# Altium schematic sheet SmithBoard Rev B.SchDoc
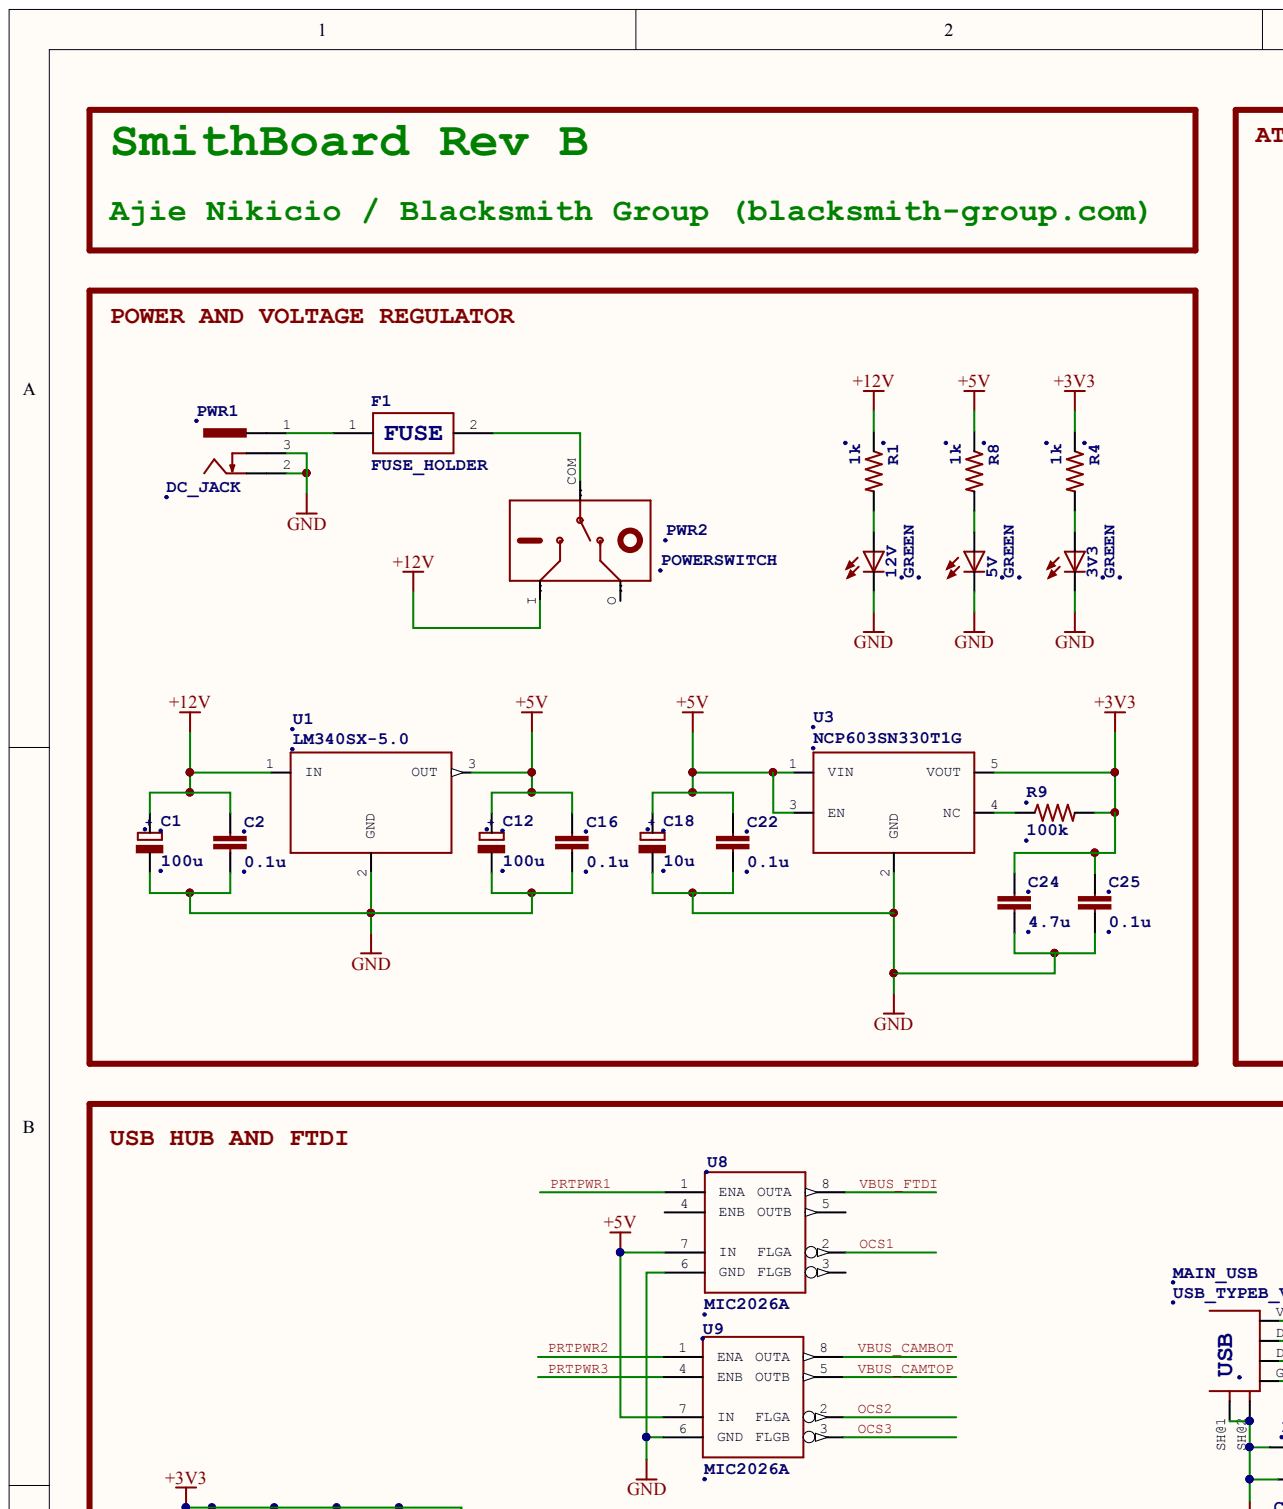
[diagram p0: SmithBoard Rev B.SchDoc, top left]
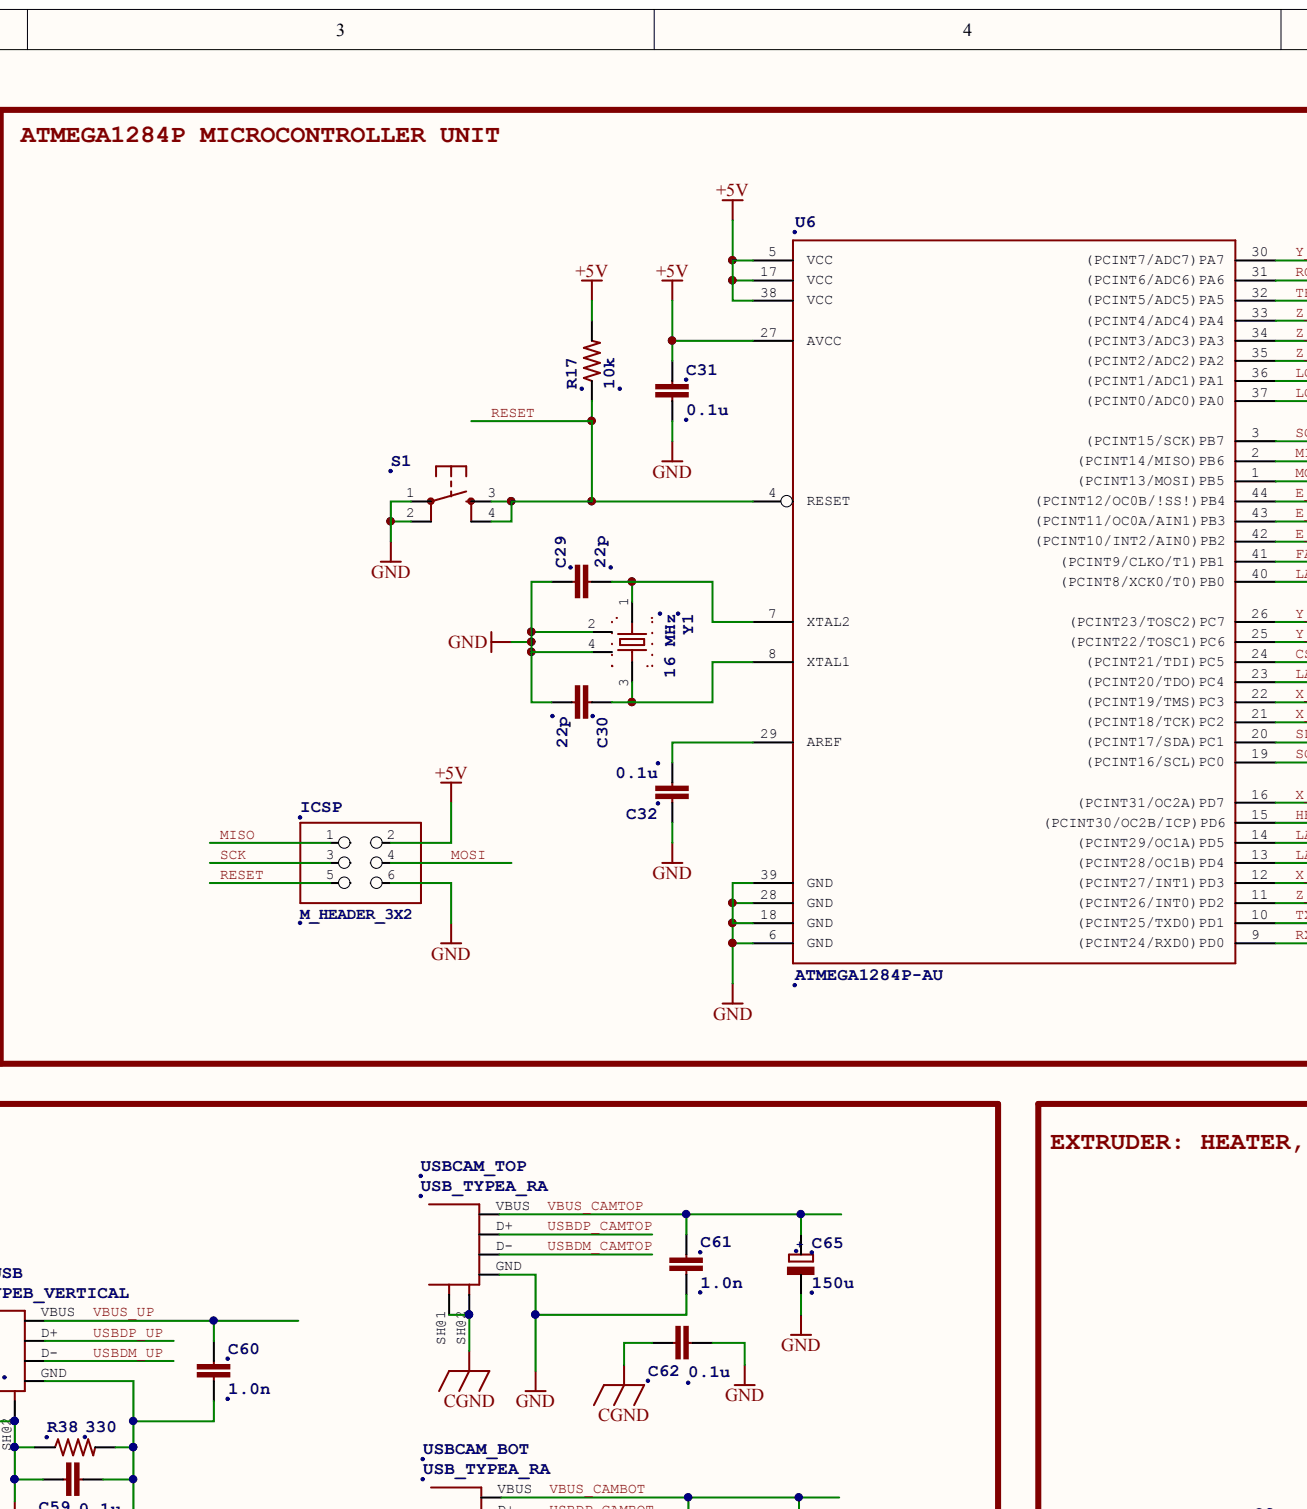
[diagram p1: SmithBoard Rev B.SchDoc, top centre]
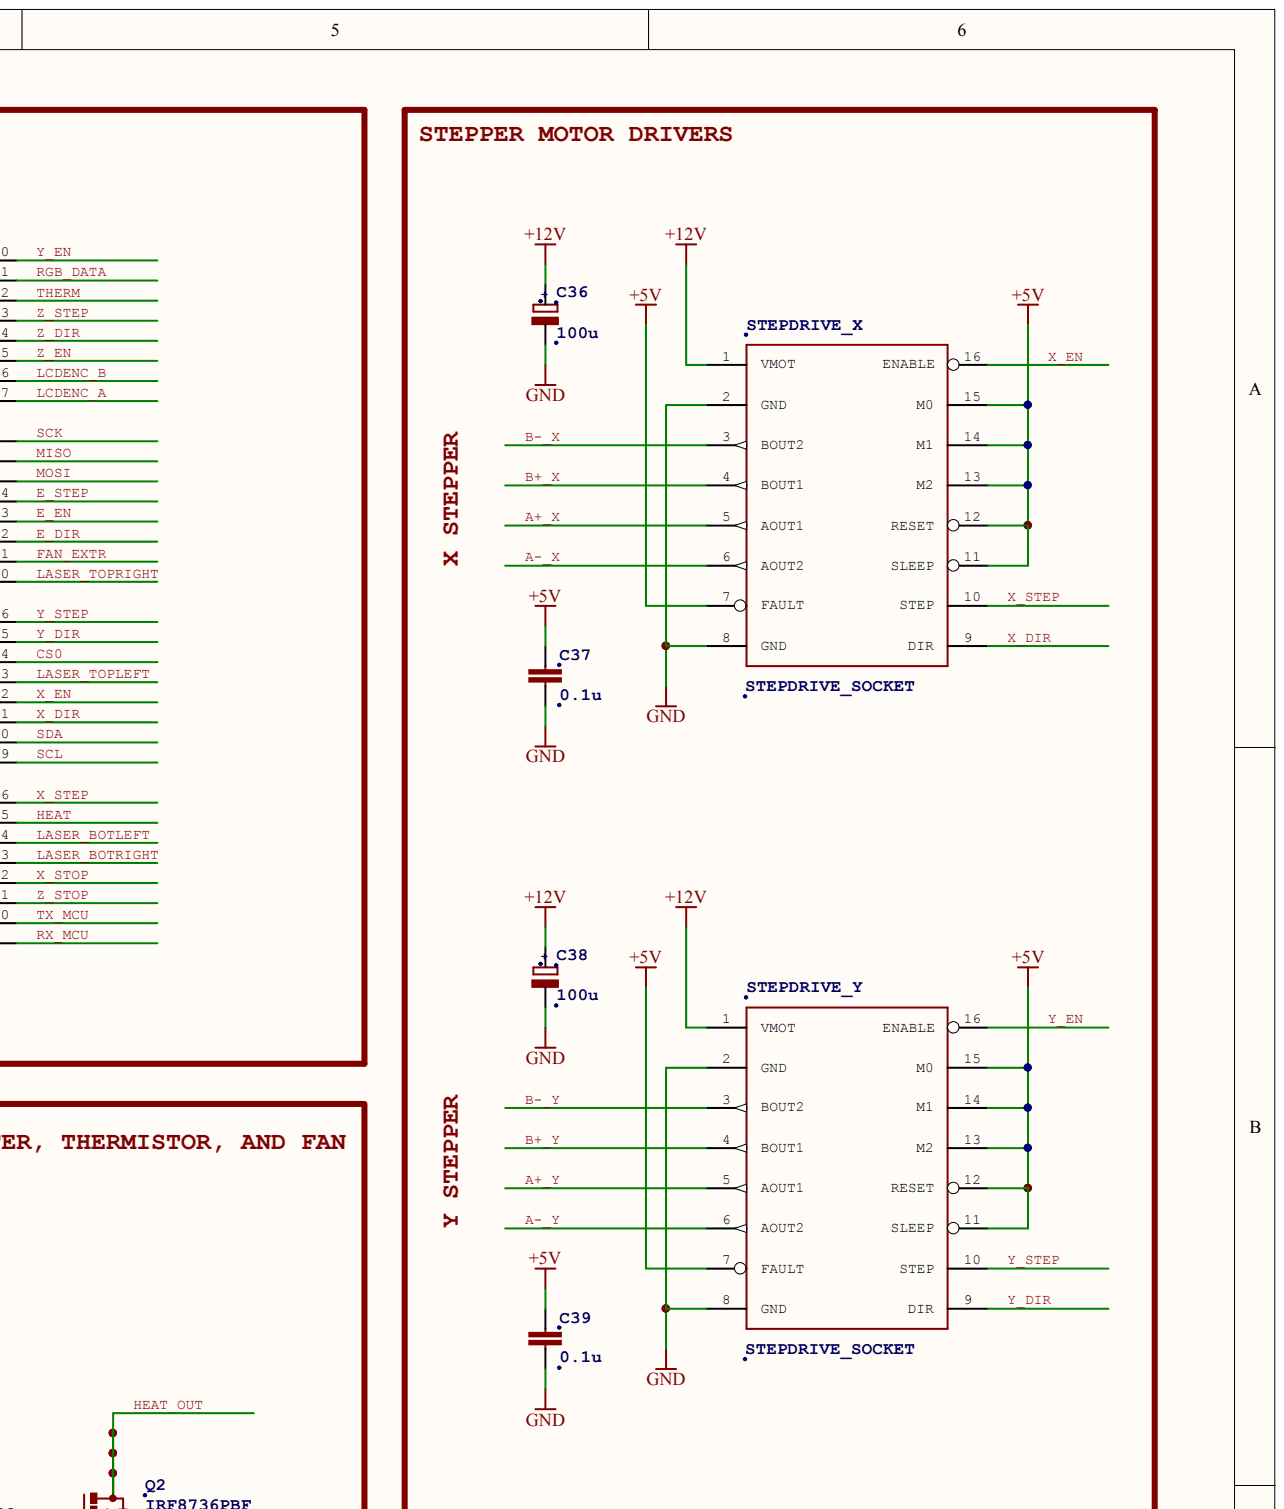
[diagram p2: SmithBoard Rev B.SchDoc, top right]
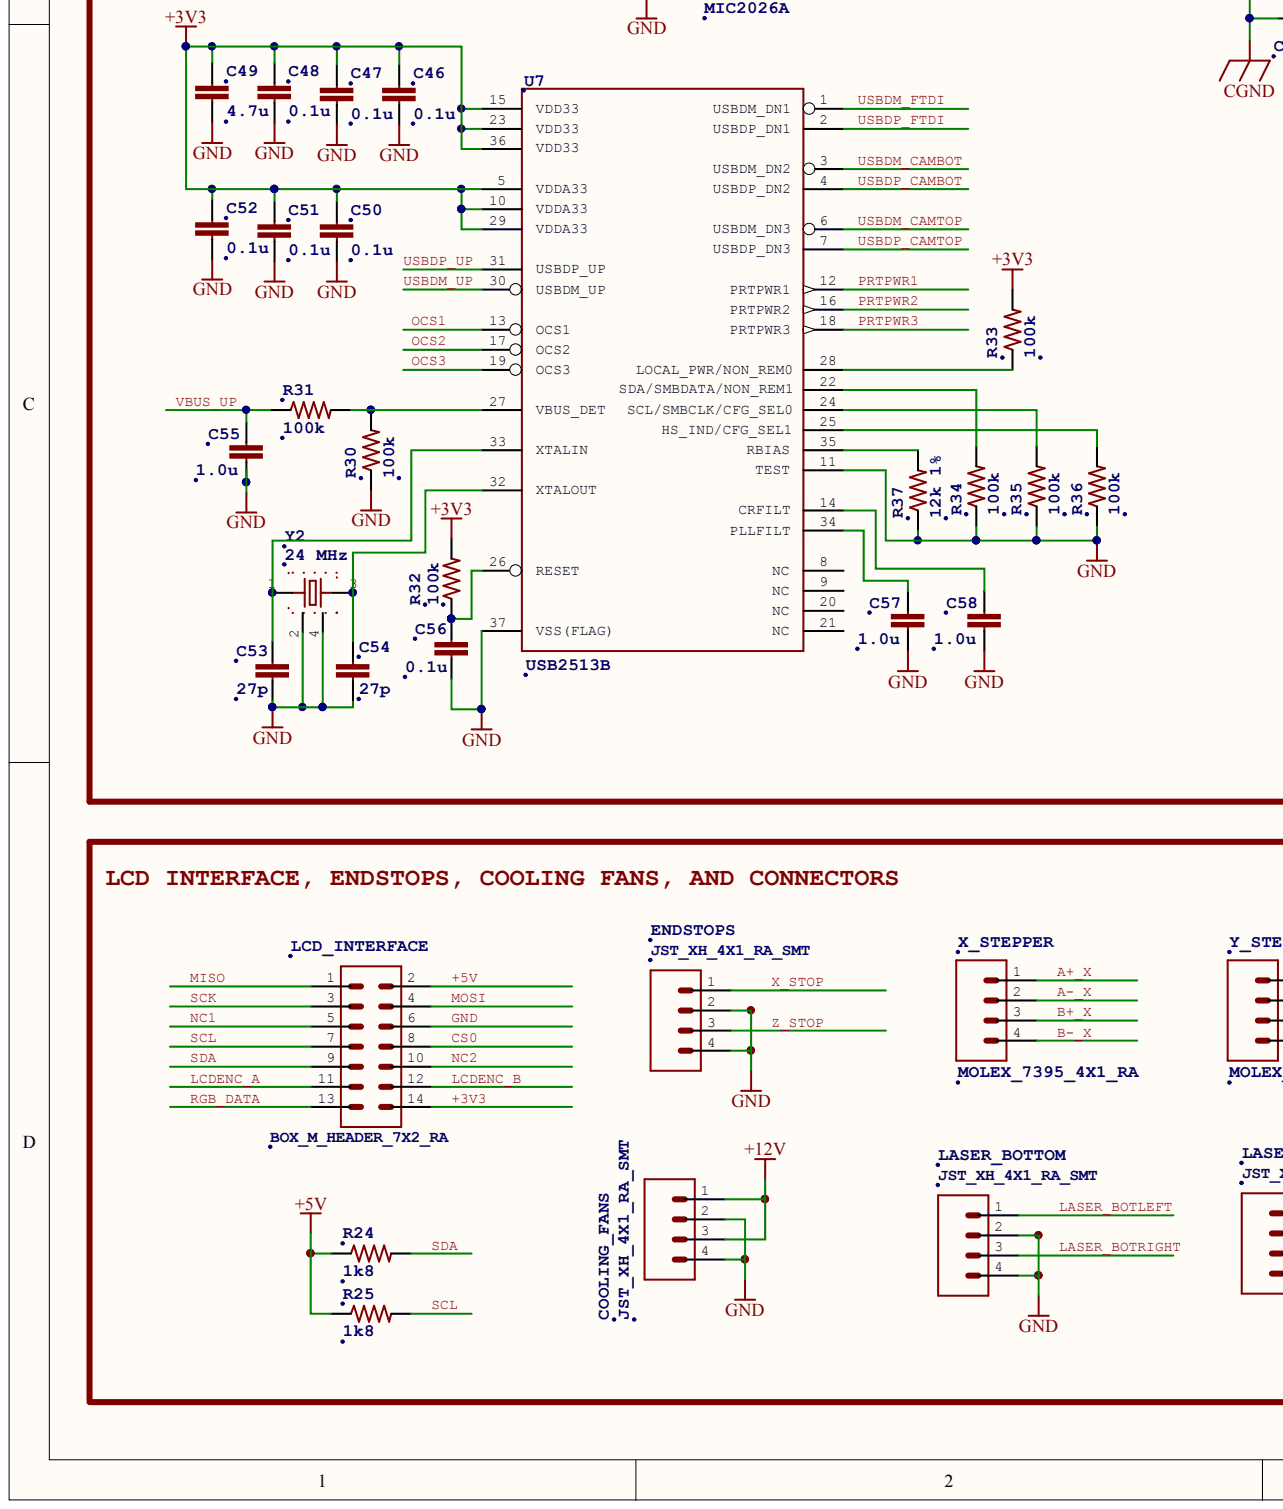
[diagram p3: SmithBoard Rev B.SchDoc, bottom left]
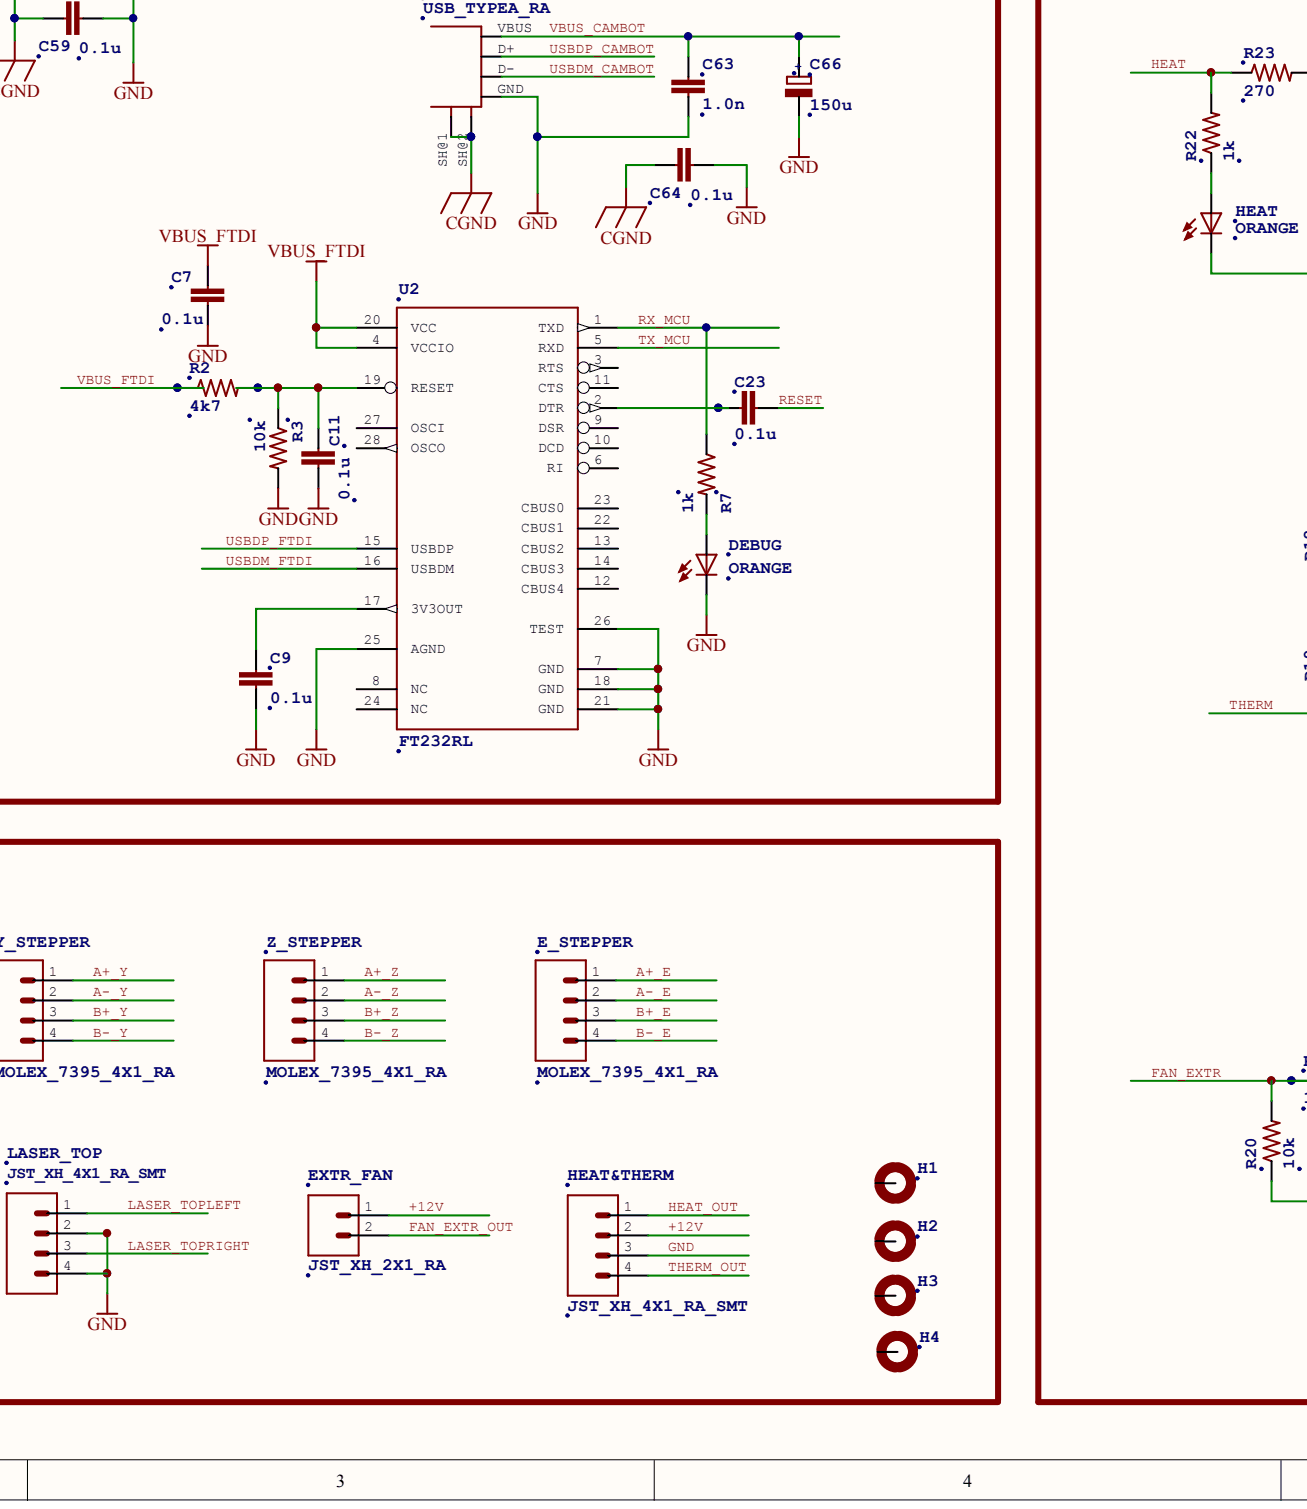
[diagram p4: SmithBoard Rev B.SchDoc, bottom centre]
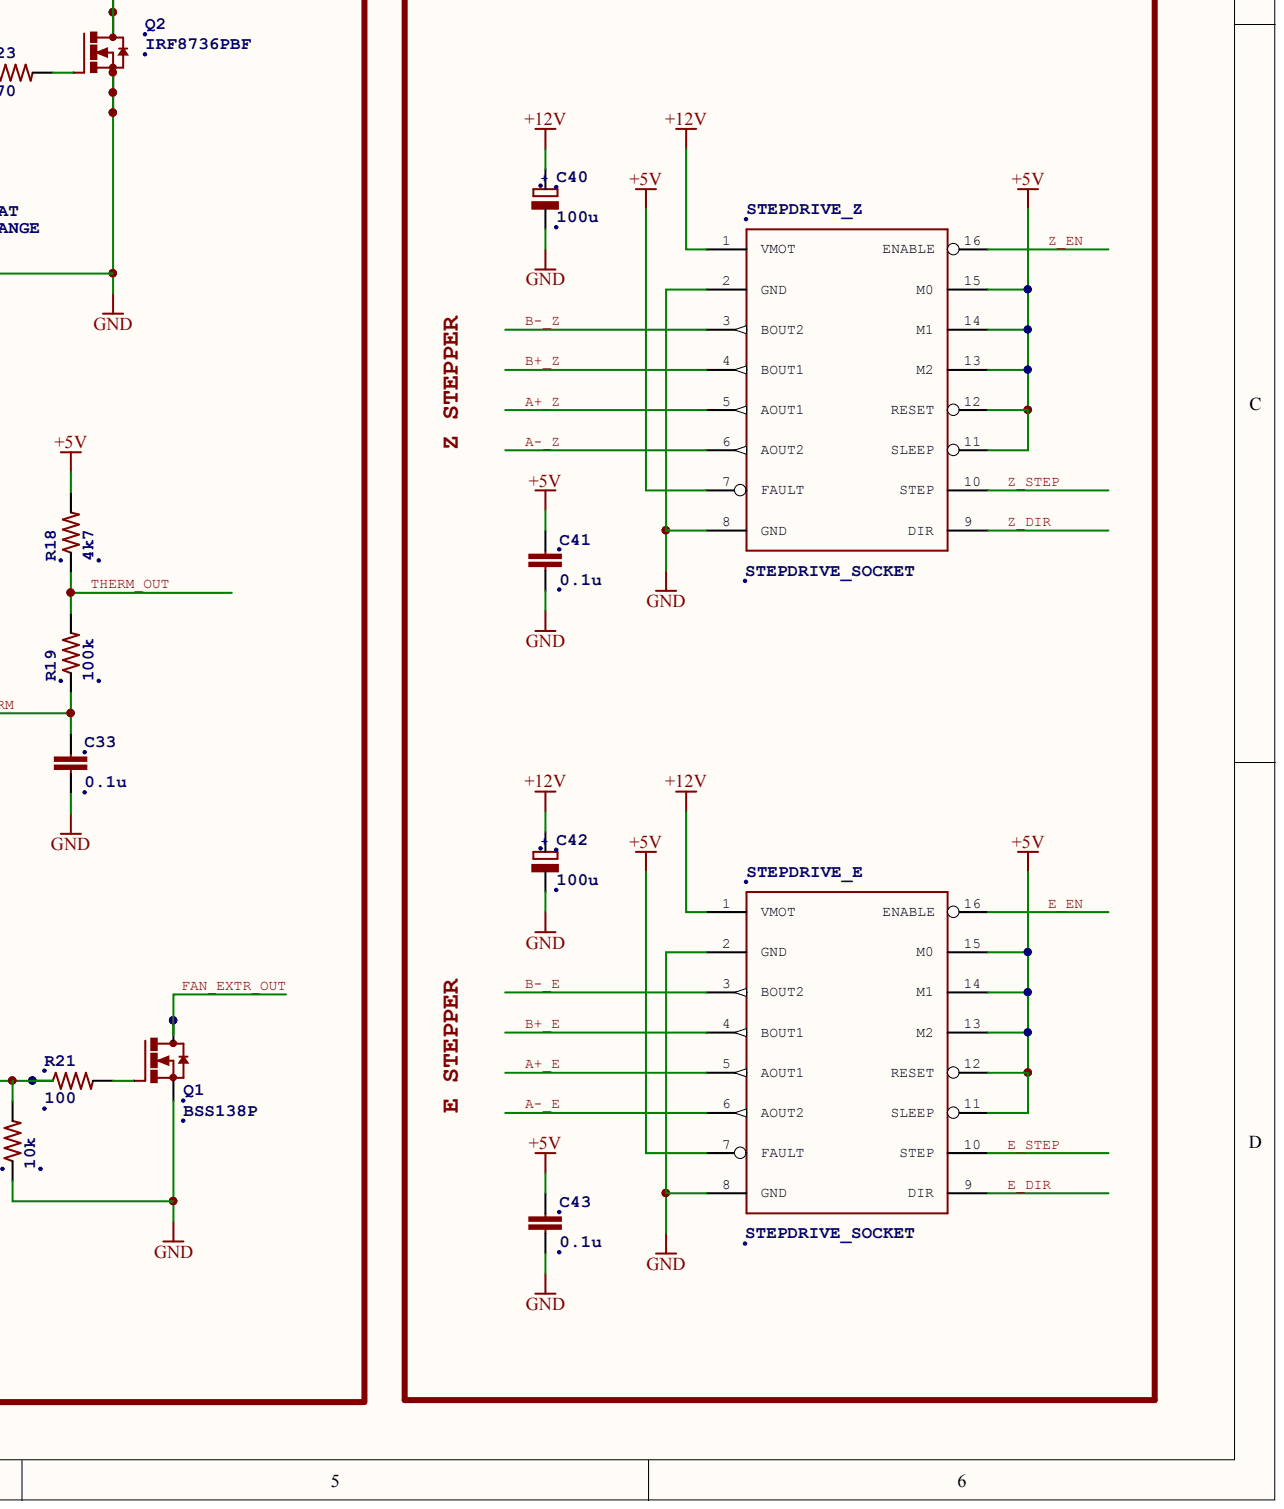
[diagram p5: SmithBoard Rev B.SchDoc, bottom right]

## Parts
3V3  GREEN
5V  GREEN
12V  GREEN
C1  100u
C2  0.1u
C7  0.1u
C9  0.1u
C11  0.1u
C12  100u
C16  0.1u
C18  10u
C22  0.1u
C23  0.1u
C24  4.7u
C25  0.1u
C29  22p
C30  22p
C31  0.1u
C32  0.1u
C33  0.1u
C36  100u
C37  0.1u
C38  100u
C39  0.1u
C40  100u
C41  0.1u
C42  100u
C43  0.1u
C46  0.1u
C47  0.1u
C48  0.1u
C49  4.7u
C50  0.1u
C51  0.1u
C52  0.1u
C53  27p
C54  27p
C55  1.0u
C56  0.1u
C57  1.0u
C58  1.0u
C59  0.1u
C60  1.0n
C61  1.0n
C62  0.1u
C63  1.0n
C64  0.1u
C65  150u
C66  150u
COOLING_FANS  JST_XH_4X1_RA_SMT
DEBUG  ORANGE
E_STEPPER  MOLEX_7395_4X1_RA
ENDSTOPS  JST_XH_4X1_RA_SMT
EXTR_FAN  JST_XH_2X1_RA
F1  FUSE_HOLDER
H1  MOUNT-PAD-ROUND3.3  (value not drawn)
H2  MOUNT-PAD-ROUND3.3  (value not drawn)
H3  MOUNT-PAD-ROUND3.3  (value not drawn)
H4  MOUNT-PAD-ROUND3.3  (value not drawn)
HEAT  ORANGE
HEAT&THERM  JST_XH_4X1_RA_SMT
ICSP  M_HEADER_3X2
LASER_BOTTOM  JST_XH_4X1_RA_SMT
LASER_TOP  JST_XH_4X1_RA_SMT
LCD_INTERFACE  BOX_M_HEADER_7X2_RA
MAIN_USB  USB_TYPEB_VERTICAL
PWR1  DC_JACK
PWR2  POWERSWITCH
Q1  BSS138P
Q2  IRF8736PBF
R1  1k
R2  4k7
R3  10k
R4  1k
R7  1k
R8  1k
R9  100k
R17  10k
R18  4k7
R19  100k
R20  10k
R21  100
R22  1k
R23  270
R24  1k8
R25  1k8
R30  100k
R31  100k
R32  100k
R33  100k
R34  100k
R35  100k
R36  100k
R37  12k 1%
R38  330
S1  TACT_SWITCH_SMT  (value not drawn)
STEPDRIVE_E  STEPDRIVE_SOCKET
STEPDRIVE_X  STEPDRIVE_SOCKET
STEPDRIVE_Y  STEPDRIVE_SOCKET
STEPDRIVE_Z  STEPDRIVE_SOCKET
U1  LM340SX-5.0
U2  FT232RL
U3  NCP603SN330T1G
U6  ATMEGA1284P-AU
U7  USB2513B
U8  MIC2026A
U9  MIC2026A
USBCAM_BOT  USB_TYPEA_RA
USBCAM_TOP  USB_TYPEA_RA
X_STEPPER  MOLEX_7395_4X1_RA
Y1  16 MHz
Y2  24 MHz
Y_STEPPER  MOLEX_7395_4X1_RA
Z_STEPPER  MOLEX_7395_4X1_RA

## Nets
CGND: C59.1 C62.1 C64.1 MAIN_USB.SH@1(USB) MAIN_USB.SH@2 R38.1 USBCAM_BOT.SH@1 USBCAM_BOT.SH@2 USBCAM_TOP.SH@1 USBCAM_TOP.SH@2
+3V3: C24.1 C25.1 C46.1 C47.1 C48.1 C49.1 C50.1 C51.1 C52.1 LCD_INTERFACE.14 R4.1 R9.2 R32.2 R33.2 U3.5(VOUT) U7.5(VDDA33) U7.10(VDDA33) U7.15(VDD33) U7.23(VDD33) U7.29(VDDA33) U7.36(VDD33)
+5V: C12.+ C16.1 C18.+ C22.1 C31.1 C37.1 C39.1 C41.1 C43.1 ICSP.2 LCD_INTERFACE.2 R8.1 R17.2 R18.2 R24.1 R25.1 STEPDRIVE_E.7(FAULT) STEPDRIVE_E.11(SLEEP) STEPDRIVE_E.12(RESET) STEPDRIVE_E.13(M2) STEPDRIVE_E.14(M1) STEPDRIVE_E.15(M0) STEPDRIVE_X.7(FAULT) STEPDRIVE_X.11(SLEEP) STEPDRIVE_X.12(RESET) STEPDRIVE_X.13(M2) STEPDRIVE_X.14(M1) STEPDRIVE_X.15(M0) STEPDRIVE_Y.7(FAULT) STEPDRIVE_Y.11(SLEEP) STEPDRIVE_Y.12(RESET) STEPDRIVE_Y.13(M2) STEPDRIVE_Y.14(M1) STEPDRIVE_Y.15(M0) STEPDRIVE_Z.7(FAULT) STEPDRIVE_Z.11(SLEEP) STEPDRIVE_Z.12(RESET) STEPDRIVE_Z.13(M2) STEPDRIVE_Z.14(M1) STEPDRIVE_Z.15(M0) U1.3 U3.1(VIN) U3.3(EN) U6.5(VCC) U6.17(VCC) U6.27(AVCC) U6.38(VCC) U8.7(IN) U9.7(IN)
+12V: C1.+ C2.1 C36.+ C38.+ C40.+ C42.+ COOLING_FANS.1 COOLING_FANS.3 EXTR_FAN.1 HEAT&THERM.2 PWR2.I R1.1 STEPDRIVE_E.1(VMOT) STEPDRIVE_X.1(VMOT) STEPDRIVE_Y.1(VMOT) STEPDRIVE_Z.1(VMOT) U1.1
A+_E: E_STEPPER.1 STEPDRIVE_E.5(AOUT1)
A+_X: STEPDRIVE_X.5(AOUT1) X_STEPPER.1
A+_Y: STEPDRIVE_Y.5(AOUT1) Y_STEPPER.1
A+_Z: STEPDRIVE_Z.5(AOUT1) Z_STEPPER.1
A-_E: E_STEPPER.2 STEPDRIVE_E.6(AOUT2)
A-_X: STEPDRIVE_X.6(AOUT2) X_STEPPER.2
A-_Y: STEPDRIVE_Y.6(AOUT2) Y_STEPPER.2
A-_Z: STEPDRIVE_Z.6(AOUT2) Z_STEPPER.2
B+_E: E_STEPPER.3 STEPDRIVE_E.4(BOUT1)
B+_X: STEPDRIVE_X.4(BOUT1) X_STEPPER.3
B+_Y: STEPDRIVE_Y.4(BOUT1) Y_STEPPER.3
B+_Z: STEPDRIVE_Z.4(BOUT1) Z_STEPPER.3
B-_E: E_STEPPER.4 STEPDRIVE_E.3(BOUT2)
B-_X: STEPDRIVE_X.3(BOUT2) X_STEPPER.4
B-_Y: STEPDRIVE_Y.3(BOUT2) Y_STEPPER.4
B-_Z: STEPDRIVE_Z.3(BOUT2) Z_STEPPER.4
CS0: LCD_INTERFACE.8 U6.24((PCINT21/TDI)PC5)
E_DIR: STEPDRIVE_E.9(DIR) U6.42((PCINT10/INT2/AIN0)PB2)
E_EN: STEPDRIVE_E.16(ENABLE) U6.43((PCINT11/OC0A/AIN1)PB3)
E_STEP: STEPDRIVE_E.10(STEP) U6.44((PCINT12/OC0B/!SS!)PB4)
FAN_EXTR: R20.2 R21.1 U6.41((PCINT9/CLKO/T1)PB1)
FAN_EXTR_OUT: EXTR_FAN.2 Q1.3
GND: 3V3.C 5V.C 12V.C C1.- C2.2 C7.2 C9.2 C11.2 C12.- C16.2 C18.- C22.2 C24.2 C25.2 C29.1 C30.2 C31.2 C32.1 C33.2 C36.- C37.2 C38.- C39.2 C40.- C41.2 C42.- C43.2 C46.2 C47.2 C48.2 C49.2 C50.2 C51.2 C52.2 C53.2 C54.2 C55.2 C56.2 C57.2 C58.2 C59.2 C60.2 C61.2 C62.2 C63.2 C64.2 C65.- C66.- COOLING_FANS.2 COOLING_FANS.4 DEBUG.C ENDSTOPS.2 ENDSTOPS.4 HEAT.C HEAT&THERM.3 ICSP.6 LASER_BOTTOM.2 LASER_BOTTOM.4 LASER_TOP.2 LASER_TOP.4 LCD_INTERFACE.6 MAIN_USB.GND PWR1.2 PWR1.3 Q1.2 Q2.1 Q2.2 Q2.3 R3.2 R20.1 R30.1 R34.1 R35.1 R36.1 R37.1 R38.2 S1.1 S1.2 STEPDRIVE_E.2(GND) STEPDRIVE_E.8(GND) STEPDRIVE_X.2(GND) STEPDRIVE_X.8(GND) STEPDRIVE_Y.2(GND) STEPDRIVE_Y.8(GND) STEPDRIVE_Z.2(GND) STEPDRIVE_Z.8(GND) U1.2 U2.7(GND) U2.18(GND) U2.21(GND) U2.25(AGND) U2.26(TEST) U3.2(GND) U6.6(GND) U6.18(GND) U6.28(GND) U6.39(GND) U7.11(TEST) U7.37(VSS(FLAG)) U8.6(GND) U9.6(GND) USBCAM_BOT.GND USBCAM_TOP.GND Y1.2 Y1.4 Y2.2 Y2.4
HEAT: R22.2 R23.1 U6.15((PCINT30/OC2B/ICP)PD6)
HEAT_OUT: HEAT&THERM.1 Q2.5 Q2.6 Q2.7 Q2.8
LASER_BOTLEFT: LASER_BOTTOM.1 U6.14((PCINT29/OC1A)PD5)
LASER_BOTRIGHT: LASER_BOTTOM.3 U6.13((PCINT28/OC1B)PD4)
LASER_TOPLEFT: LASER_TOP.1 U6.23((PCINT20/TDO)PC4)
LASER_TOPRIGHT: LASER_TOP.3 U6.40((PCINT8/XCK0/T0)PB0)
LCDENC_A: LCD_INTERFACE.11 U6.37((PCINT0/ADC0)PA0)
LCDENC_B: LCD_INTERFACE.12 U6.36((PCINT1/ADC1)PA1)
MISO: ICSP.1 LCD_INTERFACE.1 U6.2((PCINT14/MISO)PB6)
MOSI: ICSP.4 LCD_INTERFACE.4 U6.1((PCINT13/MOSI)PB5)
NC1: LCD_INTERFACE.5
NC2: LCD_INTERFACE.10
OCS1: U7.13(OCS1) U8.2(FLGA)
OCS2: U7.17(OCS2) U9.2(FLGA)
OCS3: U7.19(OCS3) U9.3(FLGB)
PRTPWR1: U7.12(PRTPWR1) U8.1(ENA)
PRTPWR2: U7.16(PRTPWR2) U9.1(ENA)
PRTPWR3: U7.18(PRTPWR3) U9.4(ENB)
RESET: C23.2 ICSP.5 R17.1 S1.3 S1.4 U6.4(RESET)
RGB_DATA: LCD_INTERFACE.13 U6.31((PCINT6/ADC6)PA6)
RX_MCU: R7.1 U2.1(TXD) U6.9((PCINT24/RXD0)PD0)
SCK: ICSP.3 LCD_INTERFACE.3 U6.3((PCINT15/SCK)PB7)
SCL: LCD_INTERFACE.7 R25.2 U6.19((PCINT16/SCL)PC0)
SDA: LCD_INTERFACE.9 R24.2 U6.20((PCINT17/SDA)PC1)
THERM: C33.1 R19.1 U6.32((PCINT5/ADC5)PA5)
THERM_OUT: HEAT&THERM.4 R18.1 R19.2
TX_MCU: U2.5(RXD) U6.10((PCINT25/TXD0)PD1)
USBDM_CAMBOT: U7.3(USBDM_DN2) USBCAM_BOT.D-
USBDM_CAMTOP: U7.6(USBDM_DN3) USBCAM_TOP.D-
USBDM_FTDI: U2.16(USBDM) U7.1(USBDM_DN1)
USBDM_UP: MAIN_USB.D- U7.30(USBDM_UP)
USBDP_CAMBOT: U7.4(USBDP_DN2) USBCAM_BOT.D+
USBDP_CAMTOP: U7.7(USBDP_DN3) USBCAM_TOP.D+
USBDP_FTDI: U2.15(USBDP) U7.2(USBDP_DN1)
USBDP_UP: MAIN_USB.D+ U7.31(USBDP_UP)
VBUS_CAMBOT: C63.1 C66.+ U9.8(OUTA) USBCAM_BOT.VBUS
VBUS_CAMTOP: C61.1 C65.+ U9.5(OUTB) USBCAM_TOP.VBUS
VBUS_FTDI: C7.1 R2.1 U2.4(VCCIO) U2.20(VCC) U8.8(OUTA)
VBUS_UP: C55.1 C60.1 MAIN_USB.VBUS R31.1
X_DIR: STEPDRIVE_X.9(DIR) U6.21((PCINT18/TCK)PC2)
X_EN: STEPDRIVE_X.16(ENABLE) U6.22((PCINT19/TMS)PC3)
X_STEP: STEPDRIVE_X.10(STEP) U6.16((PCINT31/OC2A)PD7)
X_STOP: ENDSTOPS.1 U6.12((PCINT27/INT1)PD3)
Y_DIR: STEPDRIVE_Y.9(DIR) U6.25((PCINT22/TOSC1)PC6)
Y_EN: STEPDRIVE_Y.16(ENABLE) U6.30((PCINT7/ADC7)PA7)
Y_STEP: STEPDRIVE_Y.10(STEP) U6.26((PCINT23/TOSC2)PC7)
Z_DIR: STEPDRIVE_Z.9(DIR) U6.34((PCINT3/ADC3)PA3)
Z_EN: STEPDRIVE_Z.16(ENABLE) U6.35((PCINT2/ADC2)PA2)
Z_STEP: STEPDRIVE_Z.10(STEP) U6.33((PCINT4/ADC4)PA4)
Z_STOP: ENDSTOPS.3 U6.11((PCINT26/INT0)PD2)
Net3V3_A: 3V3.A R4.2
Net5V_A: 5V.A R8.2
Net12V_A: 12V.A R1.2
NetC9_1: C9.1 U2.17(3V3OUT)
NetC11_1: C11.1 R2.2 R3.1 U2.19(RESET)
NetC23_1: C23.1 U2.2(DTR)
NetC29_2: C29.2 U6.7(XTAL2) Y1.1
NetC30_1: C30.1 U6.8(XTAL1) Y1.3
NetC32_2: C32.2 U6.29(AREF)
NetC53_1: C53.1 U7.33(XTALIN) Y2.1
NetC54_1: C54.1 U7.32(XTALOUT) Y2.3
NetC56_1: C56.1 R32.1 U7.26(RESET)
NetC57_1: C57.1 U7.34(PLLFILT)
NetC58_1: C58.1 U7.14(CRFILT)
NetDEBUG_A: DEBUG.A R7.2
NetF1_1: F1.1 PWR1.1
NetF1_2: F1.2 PWR2.COM
NetHEAT_A: HEAT.A R22.1
NetQ1_1: Q1.1 R21.2
NetQ2_4: Q2.4 R23.2
NetR9_1: R9.1 U3.4(NC)
NetR30_2: R30.2 R31.2 U7.27(VBUS_DET)
NetR33_1: R33.1 U7.28(LOCAL_PWR/NON_REM0)
NetR34_2: R34.2 U7.22(SDA/SMBDATA/NON_REM1)
NetR35_2: R35.2 U7.24(SCL/SMBCLK/CFG_SEL0)
NetR36_2: R36.2 U7.25(HS_IND/CFG_SEL1)
NetR37_2: R37.2 U7.35(RBIAS)

Not connected: H1 B3,3; H2 B3,3; H3 B3,3; H4 B3,3; PWR1 1@1 2@1 3@1; PWR2 COM@1 COM@2 I@1 I@2 O O@1 O@2; U2 3(RTS) 6(RI) 8(NC) 9(DSR) 10(DCD) 11(CTS) 12(CBUS4) 13(CBUS2) 14(CBUS3) 22(CBUS1) 23(CBUS0) 24(NC) 27(OSCI) 28(OSCO); U7 8(NC) 9(NC) 20(NC) 21(NC); U8 3(FLGB) 4(ENB) 5(OUTB)

## Library data of the parts
3V3 5V 12V C1 C2 C7 C9 C11 C12 C16 C18 C22-C25 C29-C33 C36-C43 C46-C66 COOLING_FANS DEBUG E_STEPPER ENDSTOPS EXTR_FAN F1 H1-H4 HEAT HEAT&THERM ICSP LASER_BOTTOM LASER_TOP LCD_INTERFACE MAIN_USB PWR1 PWR2 Q1 Q2 R1-R4 R7-R9 R17-R25 R30-R38 S1 STEPDRIVE_E STEPDRIVE_X STEPDRIVE_Y STEPDRIVE_Z U1-U3 U6-U9 USBCAM_BOT USBCAM_TOP X_STEPPER Y1 Y2 Y_STEPPER Z_STEPPER = (no symbol name)
  C1 C12 C18 C36 C38 C40 C42 C65 C66: +: +
  MAIN_USB: USB: USB
